# Altium schematic sheet Maximizer.SchDoc
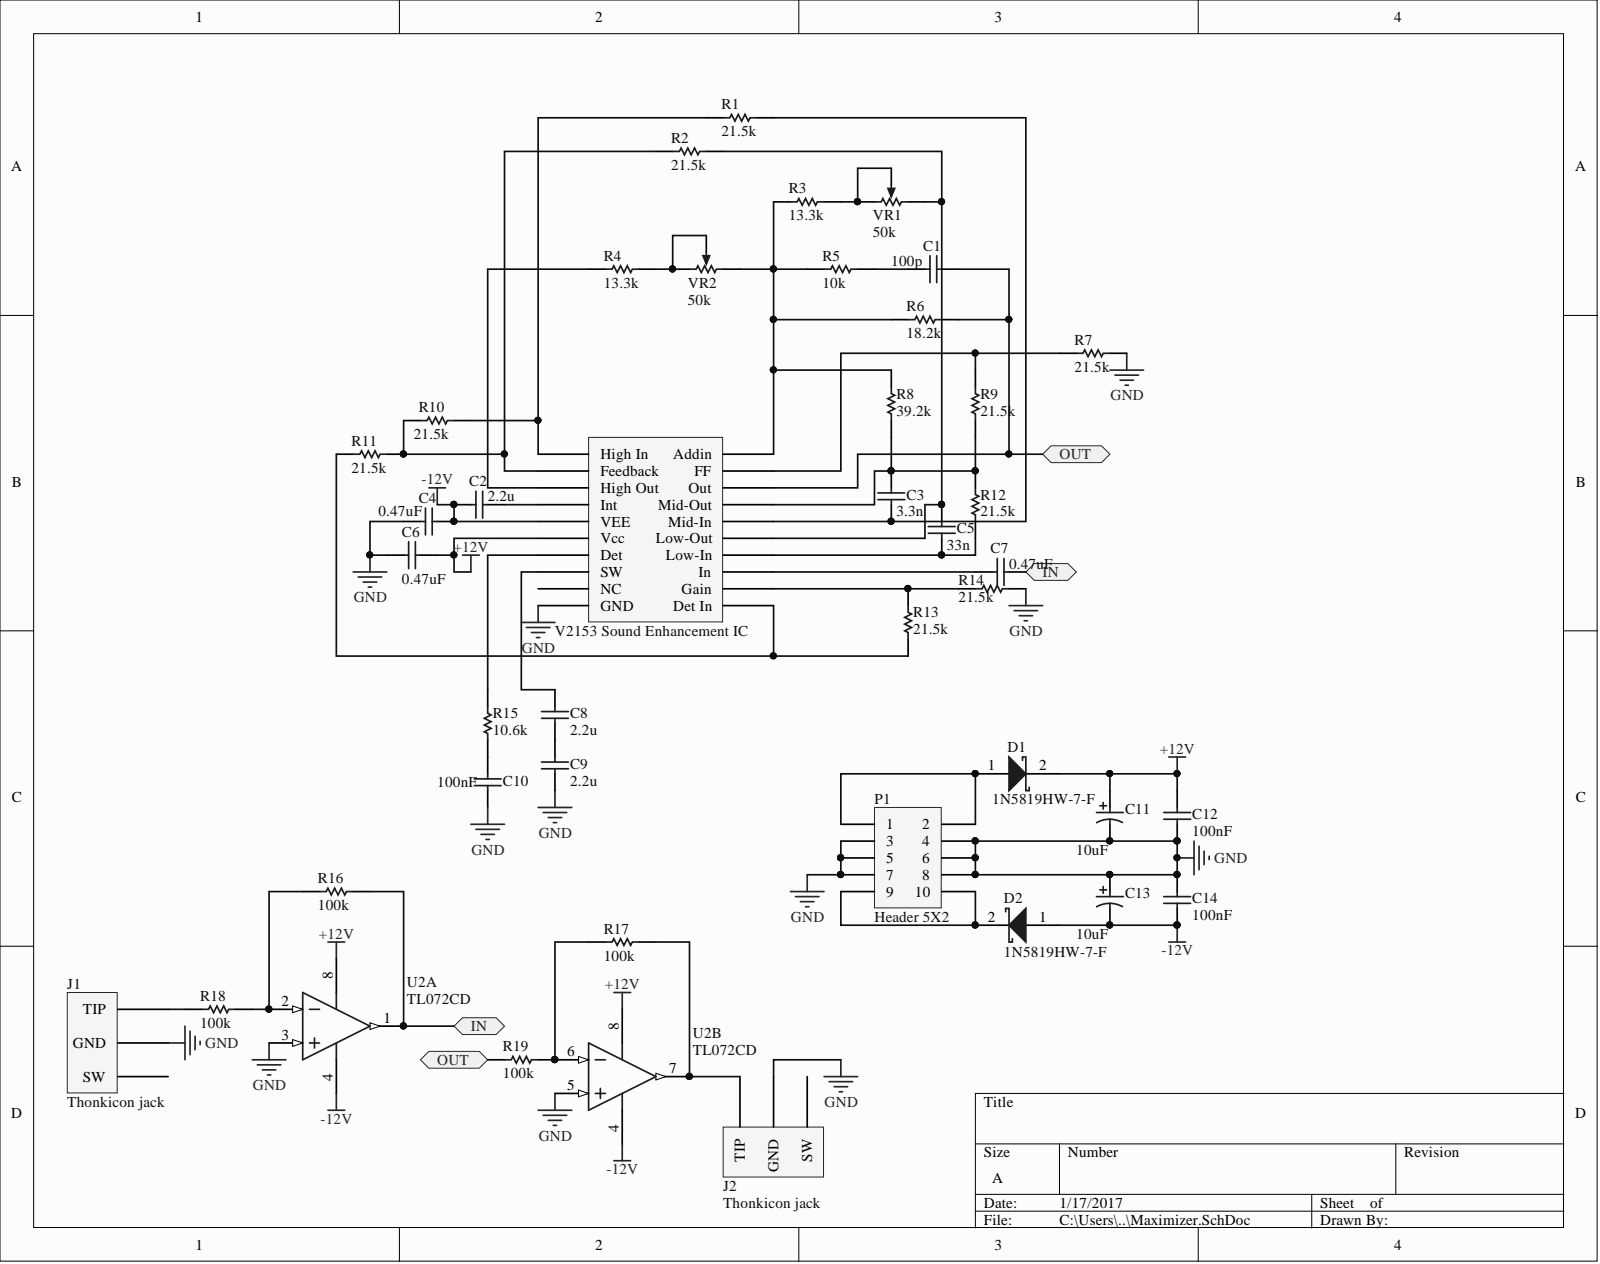
[diagram p0: Maximizer.SchDoc]

## Parts
C1  value 100p  [CAPC0603(1608)100_M]  (hidden comment: 0.)
C2  value 2.2u  [CAPC0603(1608)100_M]  (hidden comment: 0.)
C3  value 3.3n  [CAPC0603(1608)100_M]  (hidden comment: 0.)
C4  0.47uF  [CAPC0603(1608)100_M]
C5  value 33n  [CAPC0603(1608)100_M]  (hidden comment: 0.)
C6  0.47uF  [CAPC0603(1608)100_M]
C7  0.47uF  [CAPC0603(1608)100_M]
C8  value 2.2u  [CAPC0603(1608)100_M]  (hidden comment: 0.)
C9  value 2.2u  [CAPC0603(1608)100_M]  (hidden comment: 0.)
C10  100nF  [CAPC0603(1608)100_M]
C11  10uF  [NIC-CAPA2-4X5.8_V]
C12  100nF  [CAPC0805(2012)75_N]
C13  10uF  [NIC-CAPA2-4X5.8_V]
C14  100nF  [CAPC0805(2012)75_N]
D1  1N5819HW-7-F  [DIOD-SOD-123-2_V]
D2  1N5819HW-7-F  [DIOD-SOD-123-2_V]
J1  Thonkicon jack  [Thonkiconn Pot PJ301M]
J2  Thonkicon jack  [Thonkiconn Pot PJ301M]
P1  Header 5X2  [HDR2X5]
R1  21.5k  [RESC0603(1608)_M]
R2  21.5k  [RESC0603(1608)_M]
R3  13.3k  [RESC0603(1608)_M]
R4  13.3k  [RESC0603(1608)_M]
R5  10k  [RESC0603(1608)_M]
R6  18.2k  [RESC0603(1608)_M]
R7  21.5k  [RESC0603(1608)_M]
R8  39.2k  [RESC0603(1608)_M]
R9  21.5k  [RESC0603(1608)_M]
R10  21.5k  [RESC0603(1608)_M]
R11  21.5k  [RESC0603(1608)_M]
R12  21.5k  [RESC0603(1608)_M]
R13  21.5k  [RESC0603(1608)_M]
R14  21.5k  [RESC0603(1608)_M]
R15  10.6k  [RESC0603(1608)_M]
R16  100k  [RESC0603(1608)_M]
R17  100k  [RESC0603(1608)_M]
R18  100k  [RESC0603(1608)_M]
R19  100k  [RESC0603(1608)_M]
U1  V2153 Sound Enhancement IC  [SOIC20 - duplicate]
U2  TL072CD  [D0008A_M]  (parts A,B)
VR1  50k  [SonGueiPot]
VR2  50k  [SonGueiPot]

## Ports
IN, OUT

## Nets
+12V: C6.2 C11.1 C12.2 D1.2 U1.6(Vcc) U2.8
-12V: C2.1 C4.2 C13.2 C14.1 D2.1 U1.5(VEE) U2.4
GND: C4.1 C6.1 C9.1 C10.2 C11.2 C12.1 C13.1 C14.2 J1.1 J2.1 P1.3 P1.4 P1.5 P1.6 P1.7 P1.8 R7.1 R14.1 U1.10(GND) U2.3 U2.5
IN: C7.2 R16.1 U2.1 ; port IN
OUT: C1.2 R6.1 R19.2 U1.18(Out) ; port OUT
NetC1_1: C1.1 R5.1
NetC2_2: C2.2 U1.4(Int)
NetC3_1: C3.1 R1.1 U1.16(Mid-In)
NetC3_2: C3.2 R8.1 R9.2 R12.1 U1.17(Mid-Out)
NetC5_1: C5.1 R12.2 U1.14(Low-In)
NetC5_2: C5.2 R2.1 U1.15(Low-Out) VR1.3
NetC7_1: C7.1 U1.13(In)
NetC8_1: C8.1 C9.2
NetC8_2: C8.2 U1.8(SW)
NetC10_1: C10.1 R15.1
NetD1_1: D1.1 P1.1 P1.2
NetD2_2: D2.2 P1.9 P1.10
NetJ1_3: J1.3 R18.2
NetJ2_3: J2.3 R17.1 U2.7
NetR1_2: R1.2 R10.1 U1.1(High_In)
NetR2_2: R2.2 R10.2 R11.1 U1.2(Feedback)
NetR3_1: R3.1 VR1.1 VR1.2
NetR3_2: R3.2 R5.2 R6.2 R8.2 U1.20(Addin) VR2.3
NetR4_1: R4.1 VR2.1 VR2.2
NetR4_2: R4.2 U1.3(High_Out)
NetR7_2: R7.2 R9.1 U1.19(FF)
NetR11_2: R11.2 R13.2 U1.11(Det_In)
NetR13_1: R13.1 R14.2 U1.12(Gain)
NetR15_2: R15.2 U1.7(Det)
NetR16_2: R16.2 R18.1 U2.2
NetR17_2: R17.2 R19.1 U2.6

Not connected: J1 2; J2 2; U1 9(NC)

## Library data of the parts
C1 = CMP-1035-00002-1: CAP; Mfr: AVX; Mfr_Pn: 06032A101JAT2A; Case-EIA: 0603; Tolderance: 10%; Component Kind: Standard; Distributor: Mouser; Distributor_Pn: 581-06032A101JAT2A; Library Name: Altium Content Vault
C2 C8 C9 = CMP-1035-00002-1: CAP; Mfr: Murata; Mfr_Pn: GRM188R61E225KA12D; Case-EIA: 0603; Tolderance: 10%; Component Kind: Standard; Distributor: Mouser; Distributor_Pn: 81-GRM188R61E225KA2D; Library Name: Altium Content Vault
C3 = CMP-1035-00002-1: CAP; Mfr: AVX; Mfr_Pn: 06035A332JAT2A; Case-EIA: 0603; Tolderance: 10%; Component Kind: Standard; Distributor: Mouser; Distributor_Pn: 581-06035A332JAT2A; Library Name: Altium Content Vault
C4 C6 C7 = CMP-1035-00002-1: CAP; Mfr: Murata; Mfr_Pn: GCM188R71E474KA64J; Component Kind: Standard; Distributor: Mouser; Distributor_Pn: 81-GCM188R71E474KA4J; Library Name: Altium Content Vault
C5 = CMP-1035-00002-1: CAP; Mfr: Murata; Mfr_Pn: GRM188R71H333KA61D; Case-EIA: 0603; Tolderance: 10%; Component Kind: Standard; Distributor: Mouser; Distributor_Pn: 81-GRM188R71H333KA61; Library Name: Altium Content Vault
C10 = CMP-1035-00002-1: CAP; Mfr: AVX; Mfr_Pn: MM035C104KCZ2A; Component Kind: Standard; Distributor: Mouser; Distributor_Pn: 581-MM035C104KCZ2A; Library Name: Altium Content Vault
C11 C13 = CMP-0687-00016-1: Aluminum Electrolytic Capacitor, UD Series, Low Impedance, 10 uF, 25 V, + / - 20%, -55 to 105 degC, Chip Type, 4 x 5.8 mm D x L, Pb-Free, Reel; Mfr: Nichicon; Mfr_Pn: UUD1E100MCL1GS; Component Kind: Standard; Distributor: Mouser; Distributor_Pn: 647-UUD1E100MCL; Library Name: Altium Content Vault
C12 C14 = CMP-1036-00012-1: CAP 0.5pF 25V ±0.1pF 0805 (2012 Metric) Thickness 0.75mm SMD; Mfr: AVX; Mfr_Pn: 08053F102K4T2A; Component Kind: Standard; Distributor: Mouser; Distributor_Pn: 581-08053F102K4T2A; Library Name: Altium Content Vault
D1 D2 = CMP-0303-00003-1: 1.0 A Surface Mount Schottky Barrier Rectifier, 40 V, -65 to 125 degC, 2-Pin SOD-123 Package, RoHS, Tape and Reel; Mfr: Diodes Inc.; Component Kind: Standard; Distributor: Mouser; Distributor_Pn: 621-1N5819HW-F; Library Name: Altium Content Vault; Mfr_Pn: 1N5819HW-7-F
P1 = Header 5X2: Header, 5-Pin, Dual row; Component Kind: Standard; LatestRevisionDate: 17-Jul-2002; LatestRevisionNote: Re-released for DXP Platform.; Library Name: Miscellaneous Connectors.IntLib; Signal Integrity: Connector; Publisher: Altium Limited
R1 R2 R7 R9-R14 = CMP-1012-00002-1: Res; Mfr: Susumu; Mfr_Pn: RG1608P-2152-D-T5; Case-EIA: 0603; Tolerance: 5 %; Component Kind: Standard; Distributor: Mouser; Distributor_Pn: 754-RG1608P-2152DT5; Library Name: Altium Content Vault; Value: 21.5k
R3 R4 = CMP-1012-00002-1: Res; Mfr: Susumu; Mfr_Pn: RR0816P-1332-D-13C; Case-EIA: 0603; Tolerance: 5 %; Component Kind: Standard; Distributor: Mouser; Distributor_Pn: 754-RR0816P-1332D13C; Library Name: Altium Content Vault; Value: 13.3k
R5 = CMP-1012-00002-1: Res; Mfr: Susumu; Mfr_Pn: RR0816P-103-D; Case-EIA: 0603; Tolerance: 5 %; Component Kind: Standard; Distributor: Mouser; Distributor_Pn: 754-RR0816P-103D; Library Name: Altium Content Vault; Value: 10k
R6 = CMP-1012-00002-1: Res; Mfr: Susumu; Mfr_Pn: RR0816P-1822-D-26C; Case-EIA: 0603; Tolerance: 5 %; Component Kind: Standard; Distributor: Mouser; Distributor_Pn: 754-RR0816P-1822D26C; Library Name: Altium Content Vault; Value: 18.2k
R8 = CMP-1012-00002-1: Res; Mfr: KOA; Mfr_Pn: RK73H1JTTD3922F; Case-EIA: 0603; Tolerance: 5 %; Component Kind: Standard; Distributor: Mouser; Distributor_Pn: 660-RK73H1JTTD3922F; Library Name: Altium Content Vault; Value: 39.2k
R15 = CMP-1012-00002-1: Res; Mfr: Koa; Mfr_Pn: RN73H1JTTD1062D100; Case-EIA: 0603; Tolerance: 5 %; Component Kind: Standard; Distributor: Mouser; Distributor_Pn: 660-RN73H1JT1062D100; Library Name: Altium Content Vault; Value: 10.6k
R16-R19 = CMP-1012-00002-1: Res; Mfr: Susumu; Mfr_Pn: RR0816P-104-D; Case-EIA: 0603; Tolerance: 5 %; Component Kind: Standard; Distributor: Mouser; Distributor_Pn: 754-RR0816P-104D; Library Name: Altium Content Vault; Value: 100k
U1 = CoolaudioV2153: V2153; Component Kind: Standard; Library Name: EurrackParts.SchLib
U2 = CMP-0017-00467-2: Dual Low-Noise JFET-Input General-Purpose Operational Amplifier, 7 to 36 V, 0 to 70 degC, 8-pin SOIC (D8), Green (RoHS & no Sb/Br); Manufacturer: Texas Instruments; CMRR(Min)(dB): 70; GBW(Typ)(MHz): 3; IIB(Max)(pA): 200; Iq per channel(Max)(mA): 2.5; Number of Channels: 2; Offset Drift(Typ)(uV/C): 18; Rating: Catalog; Slew Rate(Typ)(V/us): 13; Sub Family: General Purpose Amplifier, High Supply Voltage Amplifier (>=30V); Total Supply Voltage(Max)(+5V is 5, +/-5V is 10): 36; Total Supply Voltage(Min)(+5V is 5, +/-5V is 10): 7; Vio (25C)(Max)(mV): 10; Code_JEDEC: MS-012; Component Kind: Standard; ComponentLink1Description: Manufacturer URL; ComponentLink1URL: http://www.ti.com/; ComponentLink2Description: Datasheet; ComponentLink2URL: http://www.ti.com/lit/ds/symlink/tl072.pdf; ComponentLink3Description: Package Specification; ComponentLink3URL: http://www.ti.com/litv/pdf/msoi002j; DatasheetVersion: SLOS080J; Eco-Plan: Green (RoHS & no Sb/Br); Library Name: Altium Content Vault; Mounting Technology: Surface Mount; PackageDescription: 8-Pin Plastic Small Outline, Body 4.9 x 3.9 mm, Pitch 1.27 mm; PackageReference: D0008A; PackageVersion: 4040047-3/M, 06/2011; PartNumber: TL072CD; Vn at 1kHz(Typ)(nV/rtHz): 18
VR1 VR2 = CMP-0972-00009-1: Trimming Potentiometer, 5K Ohm +/- 10%, -55 to 125 degC, 3-Pin 19.05 x 4.83 x 6.6 mm THD, Transparent Housing, RoHS; Manufacturer: Bourns; PartNumber: 3006P-7-502ZLF; Number of Turns: 15; Resistance (kOhm): 5; Resistance Tolerance: +/- 10%; Rotational Life: 200 Cycles; Component Kind: Standard; ComponentLink1Description: Manufacturer URL; ComponentLink1URL: http://www.bourns.com/; ComponentLink2Description: Datasheet; ComponentLink2URL: http://www.bourns.com/data/global/pdfs/3006.pdf; ComponentLink3Description: Package Specification; ComponentLink3URL: http://www.bourns.com/data/global/pdfs/3006.pdf; DatasheetVersion: Apr-14; Mounting Technology: Through Hole; PackageDescription: 3-Pin THD, Body 19.05 x 4.83 mm, Height 6.6 mm; PackageReference: 3006P-3; PackageVersion: Apr-14; RoHS: TRUE; Temperature Range: -55 to 125 degC
J1 J2 = Thonkicon jack: Component Kind: Standard; Library Name: EurrackParts.SchLib
